# Altium schematic sheet INEMOundergrad.SchDoc(INEMOundergrad)
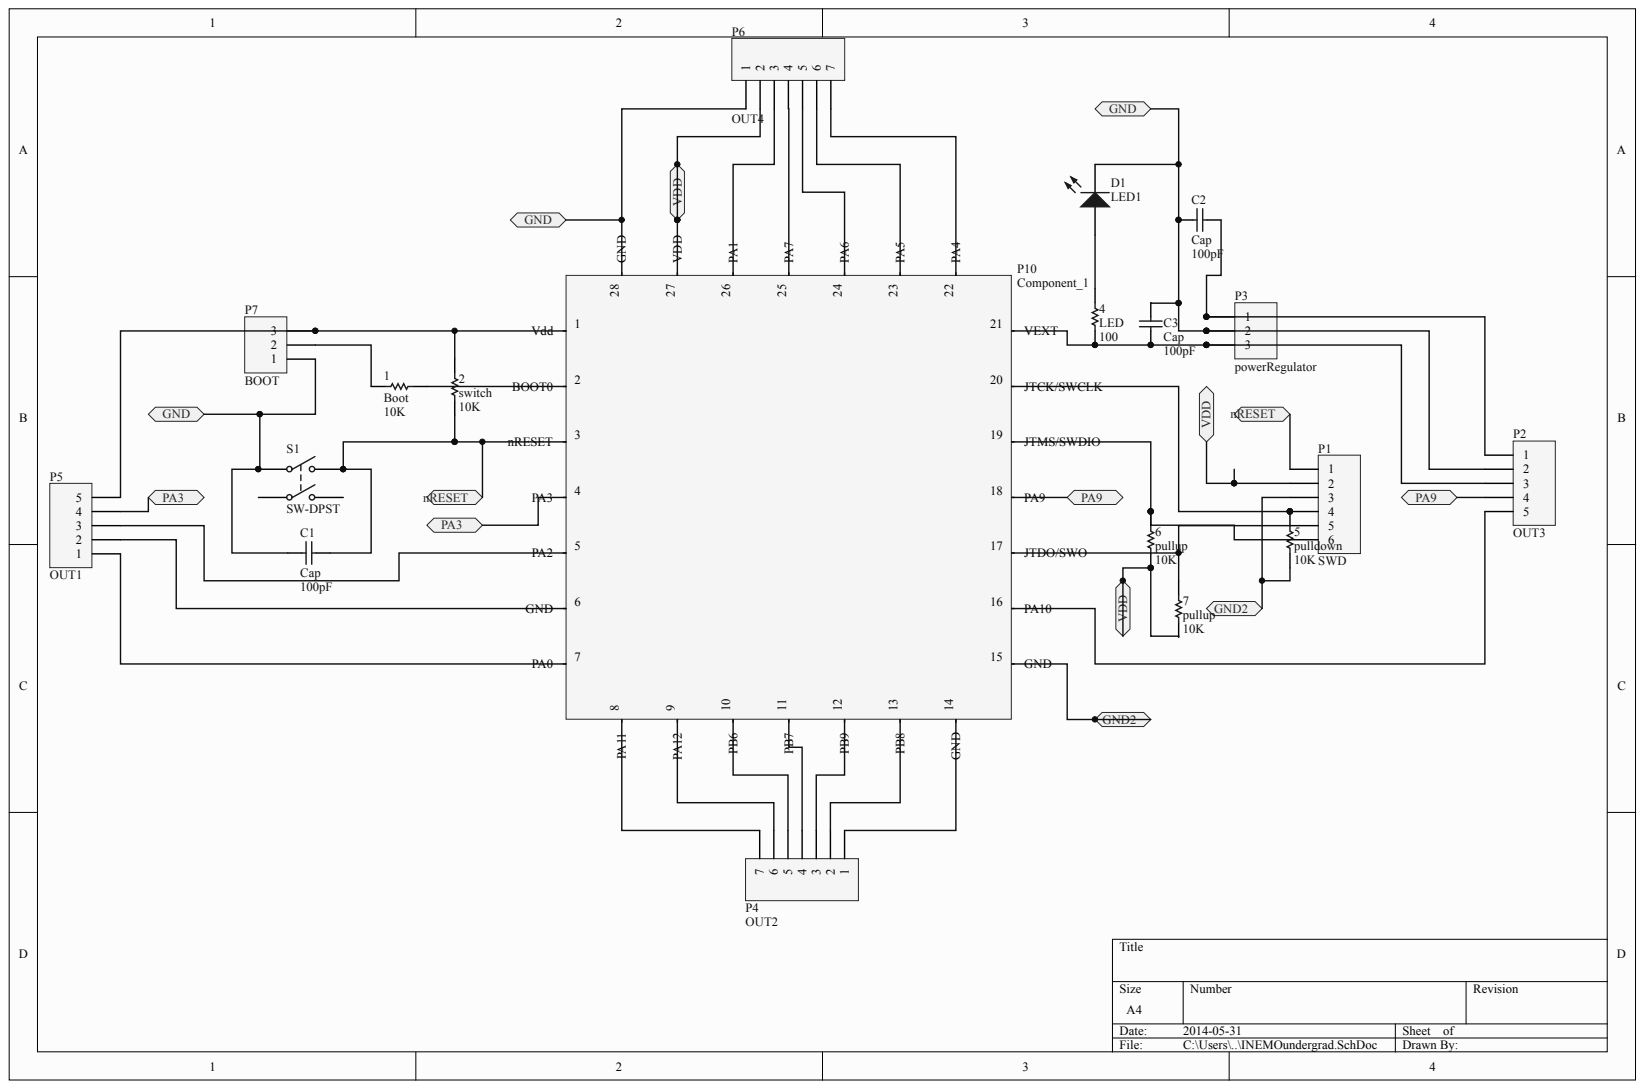
[diagram p0: INEMOundergrad.SchDoc]

## Parts
1  Boot  value 10K
2  switch  value 10K
4  LED  value 100
5  pulldown  value 10K
6  pullup  value 10K
7  pullup  value 10K
C1  Cap  value 100pF
C2  Cap  value 100pF
C3  Cap  value 100pF
D1  LED1
P1  SWD
P2  OUT3
P3  powerRegulator
P4  OUT2
P5  OUT1
P6  OUT4
P7  BOOT
P10  Component_1
S1  SW-DPST

## Ports
GND, GND2, NRESET, PA3, PA9, VDD

## Nets
GND: C1.1 C2.2 C3.2 D1.2 P2.2 P3.2 P6.1 P7.1 P10.28 S1.1 ; port GND
GND2: 5.2 P1.3 P10.15 ; port GND2
PA3: P5.4 P10.4 ; port PA3
PA9: P2.4 P10.18 ; port PA9
VDD: 6.1 7.2 P1.2 P6.2 P10.27 ; port VDD
Net1_1: 1.1 P10.2
Net1_2: 1.2 P7.2
Net2_1: 2.1 C1.2 P1.1 P10.3 S1.2 ; port NRESET
Net2_2: 2.2 P5.5 P7.3 P10.1
Net4_1: 4.1 D1.1
Net4_2: 4.2 C3.1 P2.3 P3.3 P10.21
Net5_1: 5.1 P1.4 P10.20
Net6_2: 6.2 P1.6 P10.19
Net7_1: 7.1 P1.5 P10.17
NetC2_1: C2.1 P2.1 P3.1
NetP2_5: P2.5 P10.16
NetP4_1: P4.1 P10.14
NetP4_2: P4.2 P10.13
NetP4_3: P4.3 P10.12
NetP4_4: P4.4 P10.11
NetP4_5: P4.5 P10.10
NetP4_6: P4.6 P10.9
NetP4_7: P4.7 P10.8
NetP5_1: P5.1 P10.7
NetP5_2: P5.2 P10.6
NetP5_3: P5.3 P10.5
NetP6_3: P6.3 P10.26
NetP6_4: P6.4 P10.25
NetP6_5: P6.5 P10.24
NetP6_6: P6.6 P10.23
NetP6_7: P6.7 P10.22

Not connected: S1 3 4

## Library data of the parts
1 2 4-7 C1-C3 D1 P1-P7 P10 S1 = (no symbol name)
  1 2 4-7: Published: 8-Jun-2000; LatestRevisionDate: 17-Jul-2002; LatestRevisionNote: Re-released for DXP Platform.; PackageReference: AXIAL-0.3; PackageDescription: Axial Device, Thru-Hole; 2 Leads; 0.3 in Pin Spacing
  C1-C3: Published: 8-Jun-2000; LatestRevisionDate: 17-Jul-2002; LatestRevisionNote: Re-released for DXP Platform.; PackageReference: RAD-0.3; PackageDescription: Radial Cap, Thru-Hole; 2 Leads; 0.3 in Pin Spacing
  D1: Published: 8-Jun-2000; LatestRevisionDate: 08-Jul-2005; LatestRevisionNote: Mech Layer 1 removed.; PackageReference: LED-1; PackageDescription: LED; 2 Leads
  P1-P7: LatestRevisionDate: 17-Jul-2002; LatestRevisionNote: Re-released for DXP Platform.
  S1: Published: 24-Mar-1999; LatestRevisionDate: 17-Jul-2002; LatestRevisionNote: Re-released for DXP Platform.; PackageReference: DPST-4; PackageDescription: Switch; 4 Leads
  1 2 4-7 C1-C3 D1 P1-P7 S1: Publisher: Altium Limited
